# Altium schematic sheet power-1.SchDoc
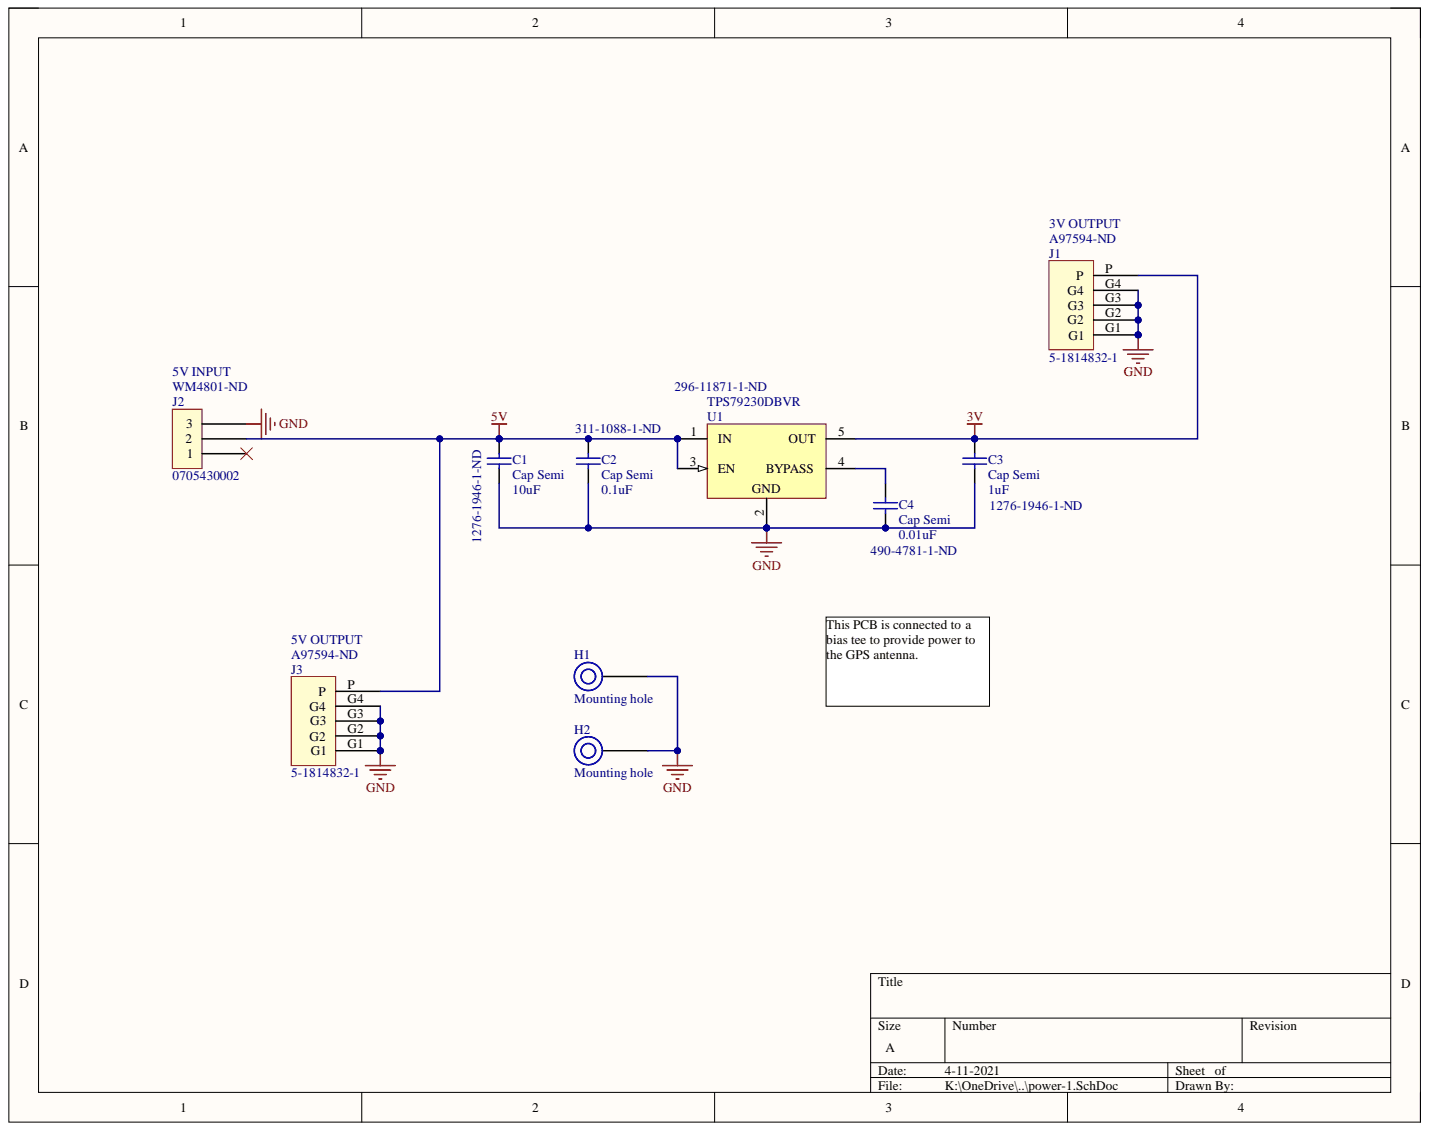
[diagram p0: power-1.SchDoc]

## Parts
C1  Cap Semi  value 10uF  Supplier Part Number 1: 1276-1946-1-ND  [1608[0603]]
C2  Cap Semi  value 0.1uF  Supplier Part Number 1: 311-1088-1-ND  [1608[0603]]
C3  Cap Semi  value 1uF  Capacitance: 1uF  Supplier Part Number 1: 1276-1946-1-ND  [1608[0603]]
C4  Cap Semi  value 0.01uF  Supplier Part Number 1: 490-4781-1-ND  [1608[0603]]
H1  Mounting hole  [M3 hole]  (no BOM)
H2  Mounting hole  [M3 hole]  (no BOM)
J1  5-1814832-1  Supplier Part Number 1: A97594-ND  [5-1814832-1]
J2  0705430002  Supplier Part Number 1: WM4801-ND  [0705430002]
J3  5-1814832-1  Supplier Part Number 1: A97594-ND  [5-1814832-1]
U1  TPS79230DBVR  [DBV0005A_L]

## Nets
3V: C3.2 J1.P U1.5(OUT)
5V: C1.2 C2.2 J2.2 J3.P U1.1(IN) U1.3(EN)
GND: C1.1 C2.1 C3.1 C4.1 H1.1 H2.1 J1.G1 J1.G2 J1.G3 J1.G4 J2.3 J3.G1 J3.G2 J3.G3 J3.G4 U1.2
NetC4_2: C4.2 U1.4(BYPASS)

Not connected: J2 1

## Library data of the parts
C1 = Cap Semi: Capacitor (Semiconductor SIM Model); Manufacturer: Samsung; Manufacturer Part Number: CL10B105KP8NNNC; Capacitance: 1uF; Dielectric: X7R; Tolerance: 10%; Voltage Rating: 10V; Case Code (Imperial): 0603; Case Code (Metric): 1608; Case/Package: 0603; Component Kind: Standard; ComponentLink1Description: https://datasheet.ciiva.com/25788/cl10b105kp8nnnc-25788304.pdf?src-supplier=Digi-Key; ComponentLink1URL: https://datasheet.ciiva.com/25788/cl10b105kp8nnnc-25788304.pdf?src-supplier=Digi-Key; ComponentLink2Description: https://datasheet.ciiva.com/16396/cl10b105kp8nnnc-16396970.pdf?src-supplier=Future+Electronics; ComponentLink2URL: https://datasheet.ciiva.com/16396/cl10b105kp8nnnc-16396970.pdf?src-supplier=Future+Electronics; ComponentLink3Description: https://datasheet.ciiva.com/26838/getdatasheetpartid-358410-26838426.pdf?src-supplier=Verical; ComponentLink3URL: https://datasheet.ciiva.com/26838/getdatasheetpartid-358410-26838426.pdf?src-supplier=Verical; Contact Plating: Tin; Depth: 0.8mm; Height: 0.8mm; LatestRevisionDate: 29-May-2009; LatestRevisionNote: IPC-7351 Footprint Added.; Lead Free: Lead Free; Length: 1.6mm; Library Name: Miscellaneous Devices.IntLib; Max Operating Temperature: 125°C; Min Operating Temperature: -55°C; Mount: Surface Mount; Package Quantity: 1; PackageDescription: Chip Capacitor; PackageReference: C1206; Packaging: Tape and Reel; Published: 8-Jun-2000; Radiation Hardening: No; RoHS: Yes; Signal Integrity: Cap Semi; Simulation: CAP; Stock 1: 10370961; Supplier 1: Digi-Key; Thickness: 0.035inch; Voltage Rating (DC): 10V; Width: 0.031inch; Publisher: Altium Limited
C2 = Cap Semi: Capacitor (Semiconductor SIM Model); Manufacturer: Yageo; Manufacturer Part Number: CC0603KRX7R7BB104; Capacitance: 100nF; Dielectric: X7R; Insulation Resistance: 100GR; Material: Ceramic; Tolerance: 10%; Voltage: 16V; Case Code (Imperial): 0603; Case Code (Metric): 1608; Case/Package: 0603; Component Kind: Standard; ComponentLink1Description: https://datasheet.ciiva.com/144/mlccorderingcode-144182.pdf?src-supplier=Digi-Key; ComponentLink1URL: https://datasheet.ciiva.com/144/mlccorderingcode-144182.pdf?src-supplier=Digi-Key; ComponentLink2Description: https://datasheet.ciiva.com/16625/upy-gphc-x7r-6-3v-to-50v-8-16625526.pdf?src-supplier=Avnet+Europe; ComponentLink2URL: https://datasheet.ciiva.com/16625/upy-gphc-x7r-6-3v-to-50v-8-16625526.pdf?src-supplier=Avnet+Europe; ComponentLink3Description: https://datasheet.ciiva.com/8033/70765-8033799.pdf?src-supplier=Element14; ComponentLink3URL: https://datasheet.ciiva.com/8033/70765-8033799.pdf?src-supplier=Element14; ComponentLink4Description: https://datasheet.ciiva.com/15165/getdatasheetpartid-63554-15165387.pdf?src-supplier=Verical; ComponentLink4URL: https://datasheet.ciiva.com/15165/getdatasheetpartid-63554-15165387.pdf?src-supplier=Verical; ComponentLink5Description: https://datasheet.ciiva.com/7978/1489800-7978141.pdf?src-supplier=Element14; ComponentLink5URL: https://datasheet.ciiva.com/7978/1489800-7978141.pdf?src-supplier=Element14; ComponentLink6Description: https://datasheet.ciiva.com/8033/1734573-8033797.pdf?src-supplier=Element14; ComponentLink6URL: https://datasheet.ciiva.com/8033/1734573-8033797.pdf?src-supplier=Element14; ComponentLink7Description: https://datasheet.ciiva.com/144/mlccorderingcode-144183.pdf?src-supplier=Digi-Key; ComponentLink7URL: https://datasheet.ciiva.com/144/mlccorderingcode-144183.pdf?src-supplier=Digi-Key; ComponentLink8Description: https://datasheet.ciiva.com/144/mlccorderingcode-144184.pdf?src-supplier=Digi-Key; ComponentLink8URL: https://datasheet.ciiva.com/144/mlccorderingcode-144184.pdf?src-supplier=Digi-Key; ComponentLink9Description: https://datasheet.ciiva.com/28450/1489800-28450552.pdf?src-supplier=Element14; ComponentLink9URL: https://datasheet.ciiva.com/28450/1489800-28450552.pdf?src-supplier=Element14; ComponentLink10Description: https://datasheet.ciiva.com/13395/upy-gphc-x7r-6-3v-to-50v-8-13395674.pdf?src-supplier=Mouser; ComponentLink10URL: https://datasheet.ciiva.com/13395/upy-gphc-x7r-6-3v-to-50v-8-13395674.pdf?src-supplier=Mouser; ComponentLink11Description: https://datasheet.ciiva.com/46430/1734573-46430156.pdf?src-supplier=Element14; ComponentLink11URL: https://datasheet.ciiva.com/46430/1734573-46430156.pdf?src-supplier=Element14; Composition: Ceramic; Depth: 0.8mm; Halogen Free: Halogen Free; Height: 0.8mm; LatestRevisionDate: 29-May-2009; LatestRevisionNote: IPC-7351 Footprint Added.; Lead Free: Lead Free; Length: 1.6mm; Library Name: Miscellaneous Devices.IntLib; Max Operating Temperature: 125°C; Min Operating Temperature: -55°C; Mount: Surface Mount; Number of Pins: 2; Package Quantity: 1; PackageDescription: Chip Capacitor; PackageReference: C1206; Packaging: Tape and Reel; Published: 8-Jun-2000; Radiation Hardening: No; REACH SVHC: No SVHC; RoHS: Yes; Signal Integrity: Cap Semi; Simulation: CAP; Stock 1: 18235990; Supplier 1: Digi-Key; Termination: SMD/SMT; Thickness: 0.035inch; Voltage Rating: 16V; Voltage Rating (DC): 16V; Width: 0.031inch; Publisher: Altium Limited
C3 = Cap Semi: Capacitor (Semiconductor SIM Model); Manufacturer: Samsung; Manufacturer Part Number: CL10B105KP8NNNC; Dielectric: X7R; Tolerance: 10%; Voltage Rating: 10V; Case Code (Imperial): 0603; Case Code (Metric): 1608; Case/Package: 0603; Component Kind: Standard; ComponentLink1Description: https://datasheet.ciiva.com/25788/cl10b105kp8nnnc-25788304.pdf?src-supplier=Digi-Key; ComponentLink1URL: https://datasheet.ciiva.com/25788/cl10b105kp8nnnc-25788304.pdf?src-supplier=Digi-Key; ComponentLink2Description: https://datasheet.ciiva.com/16396/cl10b105kp8nnnc-16396970.pdf?src-supplier=Future+Electronics; ComponentLink2URL: https://datasheet.ciiva.com/16396/cl10b105kp8nnnc-16396970.pdf?src-supplier=Future+Electronics; ComponentLink3Description: https://datasheet.ciiva.com/26838/getdatasheetpartid-358410-26838426.pdf?src-supplier=Verical; ComponentLink3URL: https://datasheet.ciiva.com/26838/getdatasheetpartid-358410-26838426.pdf?src-supplier=Verical; Contact Plating: Tin; Depth: 0.8mm; Height: 0.8mm; LatestRevisionDate: 29-May-2009; LatestRevisionNote: IPC-7351 Footprint Added.; Lead Free: Lead Free; Length: 1.6mm; Library Name: Miscellaneous Devices.IntLib; Max Operating Temperature: 125°C; Min Operating Temperature: -55°C; Mount: Surface Mount; Package Quantity: 1; PackageDescription: Chip Capacitor; PackageReference: C1206; Packaging: Tape and Reel; Published: 8-Jun-2000; Radiation Hardening: No; RoHS: Yes; Signal Integrity: Cap Semi; Simulation: CAP; Stock 1: 10370961; Supplier 1: Digi-Key; Thickness: 0.035inch; Voltage Rating (DC): 10V; Width: 0.031inch; Publisher: Altium Limited
C4 = Cap Semi: Capacitor (Semiconductor SIM Model); Manufacturer: Murata; Manufacturer Part Number: GCM188R72A103KA37D; Capacitance: 10nF; Dielectric: X7R; Tolerance: 10%; Voltage: 100V; Case Code (Imperial): 0603; Case Code (Metric): 1608; Case/Package: 0603; Component Kind: Standard; ComponentLink1Description: https://datasheet.ciiva.com/16497/product-16497251.pdf?src-supplier=Future+Electronics; ComponentLink1URL: https://datasheet.ciiva.com/16497/product-16497251.pdf?src-supplier=Future+Electronics; ComponentLink2Description: https://datasheet.ciiva.com/132/product-132907.pdf?src-supplier=Digi-Key; ComponentLink2URL: https://datasheet.ciiva.com/132/product-132907.pdf?src-supplier=Digi-Key; ComponentLink3Description: https://datasheet.ciiva.com/18183/getdatasheetpartid-178890-18183651.pdf?src-supplier=Verical; ComponentLink3URL: https://datasheet.ciiva.com/18183/getdatasheetpartid-178890-18183651.pdf?src-supplier=Verical; ComponentLink4Description: https://datasheet.ciiva.com/132/product-132908.pdf?src-supplier=Digi-Key; ComponentLink4URL: https://datasheet.ciiva.com/132/product-132908.pdf?src-supplier=Digi-Key; ComponentLink5Description: https://datasheet.ciiva.com/11786/0900766b80ef92d0-11786108.pdf?src-supplier=RSComponents; ComponentLink5URL: https://datasheet.ciiva.com/11786/0900766b80ef92d0-11786108.pdf?src-supplier=RSComponents; ComponentLink6Description: https://datasheet.ciiva.com/132/product-132909.pdf?src-supplier=Digi-Key; ComponentLink6URL: https://datasheet.ciiva.com/132/product-132909.pdf?src-supplier=Digi-Key; ComponentLink7Description: https://datasheet.ciiva.com/11619/product-11619719.pdf?src-supplier=Avnet; ComponentLink7URL: https://datasheet.ciiva.com/11619/product-11619719.pdf?src-supplier=Avnet; Depth: 0.8mm; Height: 0.8mm; LatestRevisionDate: 29-May-2009; LatestRevisionNote: IPC-7351 Footprint Added.; Lead Free: Lead Free; Length: 1.6mm; Library Name: Miscellaneous Devices.IntLib; Max Operating Temperature: 125°C; Min Operating Temperature: -55°C; Mount: Surface Mount; Package Quantity: 1; PackageDescription: Chip Capacitor; PackageReference: C1206; Packaging: Tape and Reel; Published: 8-Jun-2000; Radiation Hardening: No; Ratings: AEC-Q200; REACH SVHC: No SVHC; RoHS: Yes; Signal Integrity: Cap Semi; Simulation: CAP; Stock 1: 9017131; Supplier 1: Digi-Key; Termination: SMD/SMT; Thickness: 0.035inch; Voltage Rating: 100V; Voltage Rating (DC): 100V; Width: 0.8mm; Publisher: Altium Limited
H1 H2 = Mouting hole: Description: Mounting Hole; Library Name: holes.IntLib
J1 J3 = 5-1814832-1: Manufacturer: TE Connectivity; Body Material: Zinc; Body Plating: Gold; Connector System: Cable-to-Board; Connector Type: SMA; Dielectric Material: PTFE; Frequency: 3GHz; Gender: Female; Impedance: 50R; Insulation Resistance: 5GR; Number of Positions: 1; Plating: Nickel; Polarity: Normal; Sealable: No (Without); Tail Length: 4mm; Wire/Cable Type: Coaxial; Case/Package: SMA; Component Kind: Standard; ComponentLink1Description: https://datasheet.ciiva.com/642/eng-cd-1814832-b1-642785.pdf?src-supplier=Digi-Key; ComponentLink1URL: https://datasheet.ciiva.com/642/eng-cd-1814832-b1-642785.pdf?src-supplier=Digi-Key; ComponentLink2Description: https://datasheet.ciiva.com/642/eng-cd-1814832-b1-642785.pdf?src-supplier=Avnet; ComponentLink2URL: https://datasheet.ciiva.com/642/eng-cd-1814832-b1-642785.pdf?src-supplier=Avnet; ComponentLink3Description: https://datasheet.ciiva.com/23783/1663582-23783560.pdf?src-supplier=Newark; ComponentLink3URL: https://datasheet.ciiva.com/23783/1663582-23783560.pdf?src-supplier=Newark; ComponentLink4Description: https://datasheet.ciiva.com/16318/5-1814832-1-16318587.pdf?src-supplier=Future+Electronics; ComponentLink4URL: https://datasheet.ciiva.com/16318/5-1814832-1-16318587.pdf?src-supplier=Future+Electronics; ComponentLink5Description: https://datasheet.ciiva.com/11762/0900766b80a70d0f-11762254.pdf?src-supplier=RSComponents; ComponentLink5URL: https://datasheet.ciiva.com/11762/0900766b80a70d0f-11762254.pdf?src-supplier=RSComponents; ComponentLink6Description: https://datasheet.ciiva.com/26824/getdatasheetpartid-396696-26824822.pdf?src-supplier=Verical; ComponentLink6URL: https://datasheet.ciiva.com/26824/getdatasheetpartid-396696-26824822.pdf?src-supplier=Verical; ComponentLink7Description: https://datasheet.ciiva.com/30201/eng-cd-1814832-a-30201302.pdf?src-supplier=Onlinecomponents.com; ComponentLink7URL: https://datasheet.ciiva.com/30201/eng-cd-1814832-a-30201302.pdf?src-supplier=Onlinecomponents.com; ComponentLink8Description: https://datasheet.ciiva.com/25908/eng-cd-1814832-a-25908583.pdf?src-supplier=Avnet+Europe; ComponentLink8URL: https://datasheet.ciiva.com/25908/eng-cd-1814832-a-25908583.pdf?src-supplier=Avnet+Europe; ComponentLink9Description: https://datasheet.ciiva.com/16469/eng-cd-1814832-a-16469256.pdf?src-supplier=Avnet+Asia; ComponentLink9URL: https://datasheet.ciiva.com/16469/eng-cd-1814832-a-16469256.pdf?src-supplier=Avnet+Asia; Contact Material: Bronze; Contact Plating: Gold; Depth: 6.4mm; Description: 5-1814832-1; ELV: Compliant; Fastening Type: Threaded; Insulation Material: Polytetrafluoroethylene; Lead Free: Lead Free; Length: 0.532inch; Library Name: TE.IntLib; Manufacturer Part Number: 5-1814832-1; Max Frequency: 3GHz; Max Operating Temperature: 185°C; Min Operating Temperature: -67°C; Mount: Through Hole; Orientation: Straight; Package Quantity: 1; Packaging: Box; Pricing 1: 1 = 1.99000, 10 = 1.80400, 25 = 1.69360, 50 = 1.62000, 100 = 1.54640, 250 = 1.39908, 500 = 1.28864, 1000 = 1.10454 (USD); Radiation Hardening: No; REACH SVHC: No SVHC; RoHS: Yes; Stock 1: 34232; Supplier 1: Digi-Key; Termination: Through Hole
J2 = 0705430002: Manufacturer: Molex; Manufacturer Part Number: 70543-0002; Approvals: UL; Contact Resistance: 30mR; Current Rating: 3A; Gender: Male; Glow Wire Compliant: Non-compliant; Max Voltage Rating (AC): 250V; Number of Circuits: 3; Pitch: 2.54mm; Color: Black; Component Kind: Standard; ComponentLink1Description: https://datasheet.ciiva.com/11747/0900766b80d8d64b-11747399.pdf?src-supplier=RSComponents; ComponentLink1URL: https://datasheet.ciiva.com/11747/0900766b80d8d64b-11747399.pdf?src-supplier=RSComponents; ComponentLink2Description: https://datasheet.ciiva.com/30061/97290705430002-pcb-headers-30061900.pdf?src-supplier=Onlinecomponents.com; ComponentLink2URL: https://datasheet.ciiva.com/30061/97290705430002-pcb-headers-30061900.pdf?src-supplier=Onlinecomponents.com; ComponentLink3Description: https://datasheet.ciiva.com/19462/884643-19462365.pdf?src-supplier=Jameco; ComponentLink3URL: https://datasheet.ciiva.com/19462/884643-19462365.pdf?src-supplier=Jameco; ComponentLink4Description: https://datasheet.ciiva.com/5150/0705430002-pcb-headers-172733-5150622.pdf?src-supplier=Mouser; ComponentLink4URL: https://datasheet.ciiva.com/5150/0705430002-pcb-headers-172733-5150622.pdf?src-supplier=Mouser; ComponentLink5Description: https://datasheet.ciiva.com/16657/0705430002-pcb-headers-16657766.pdf?src-supplier=Avnet+Europe; ComponentLink5URL: https://datasheet.ciiva.com/16657/0705430002-pcb-headers-16657766.pdf?src-supplier=Avnet+Europe; Contact Material: Bronze; Contact Plating: Gold; Depth: 6.6mm; Description: 0705430002; ELV: Compliant; Flammability Rating: UL94 V-0; Height: 11.81mm; Housing Color: Black; Housing Material: Thermoplastic; Lead Free: Lead Free; Lead Pitch: 2.54mm; Length: 10.17mm; Library Name: molex.IntLib; Material: Brass; Max Current Rating: 3A; Max Operating Temperature: 105°C; Min Operating Temperature: -40°C; Mount: Through Hole; Number of Contacts: 3; Number of Positions: 3; Number of Rows: 1; Orientation: Vertical; Package Quantity: 2496; Packaging: Rail/Tube; Pricing 1: 1 = 0.92000, 10 = 0.80500, 100 = 0.69450, 500 = 0.56826, 2496 = 0.45776, 7488 = 0.44198, 12480 = 0.41041 (USD); Radiation Hardening: No; REACH SVHC: Unknown; RoHS: Yes; Stock 1: 32342; Supplier 1: Digi-Key; Termination: Solder; Voltage Rating: 250V; Voltage Rating (DC): 250V
U1 = CMP-0394-00276-2: Single Output High PSRR LDO, 100 mA, Fixed 3 V Output, 2.7 to 5.5 V Input, 5-pin SOT-23 (DBV), -40 to 125 degC, Green (RoHS & no Sb/Br); Manufacturer: Texas Instruments; Accuracy: 2%; Accuracy(%): 2; Dropout Voltage: 55mV; Fixed Output Options(V): 3; Input Bias Current: 170uA; Iout(Max)(A): 0.1; Iq(Typ)(mA): 0.2; Max Input Voltage: 5.5V; Max Output Current: 100mA; Max Output Voltage: 3V; Max Power Dissipation: 561mW; Min Current Limit: 285mA; Min Input Voltage: 2.7V; Number of Outputs: 1; Polarity: Positive; Quiescent Current: 200uA; Rating: Catalog; SubFamily: Single Channel LDO; Vdo(Typ)(mV): 55; Vin(Max)(V): 5.5; Vin(Min)(V): 2.7; Case/Package: SOT-23; Code_JEDEC: MO-178; Component Kind: Standard; ComponentLink1Description: Manufacturer URL; ComponentLink1URL: http://www.ti.com/; ComponentLink2Description: Datasheet; ComponentLink2URL: http://www.ti.com/general/docs/lit/getliterature.tsp?genericPartNumber=TPS79230&fileType=pdf; ComponentLink3Description: Package Specification; ComponentLink3URL: http://www.ti.com/litv/pdf/mpds018i; ComponentLink4Description: URL Link1; ComponentLink4URL: Samples URL:http://www.ti.com/product/tps79230#samples; ComponentLink5Description: https://datasheet.ciiva.com/22700/1887683-22700166.pdf?src-supplier=Element14; ComponentLink5URL: https://datasheet.ciiva.com/22700/1887683-22700166.pdf?src-supplier=Element14; DatasheetVersion: SLVS337B; Eco-Plan: Green (RoHS & no Sb/Br); Lead Free: Lead Free; Library Name: Altium Content Vault; Manufacturer Part Number: TPS79230DBVR; Max Operating Temperature: 125°C; Min Operating Temperature: -40°C; Min Output Voltage: 3V; Mounting Technology: Surface Mount; Nominal Output Voltage: 3V; Number of Pins: 5; Number of Regulators: 1; Output Current: 100mA; Output Type: Fixed; Output Voltage: 3V; Output Voltage Accuracy: 2%; Package Quantity: 1; PackageDescription: 5-Pin Plastic Small Outline, Body 1.60 Ãƒâ€” 2.90 mm, Pitch 0.95 mm; PackageReference: DBV0005A; PackageVersion: 4073253-4/K; Packaging: Tape and Reel; PartNumber: TPS79230DBVR; Power Dissipation: 561mW; Radiation Hardening: No; REACH SVHC: No SVHC; RoHS: Yes; Stock 1: 4533; Supplier 1: Digi-Key; Supplier Part Number 1: 296-11871-1-ND; Vout Adj(Max)(V): 3; Vout Adj(Min)(V): 3
